# Altium schematic sheet ATK-MS7620.SchDoc
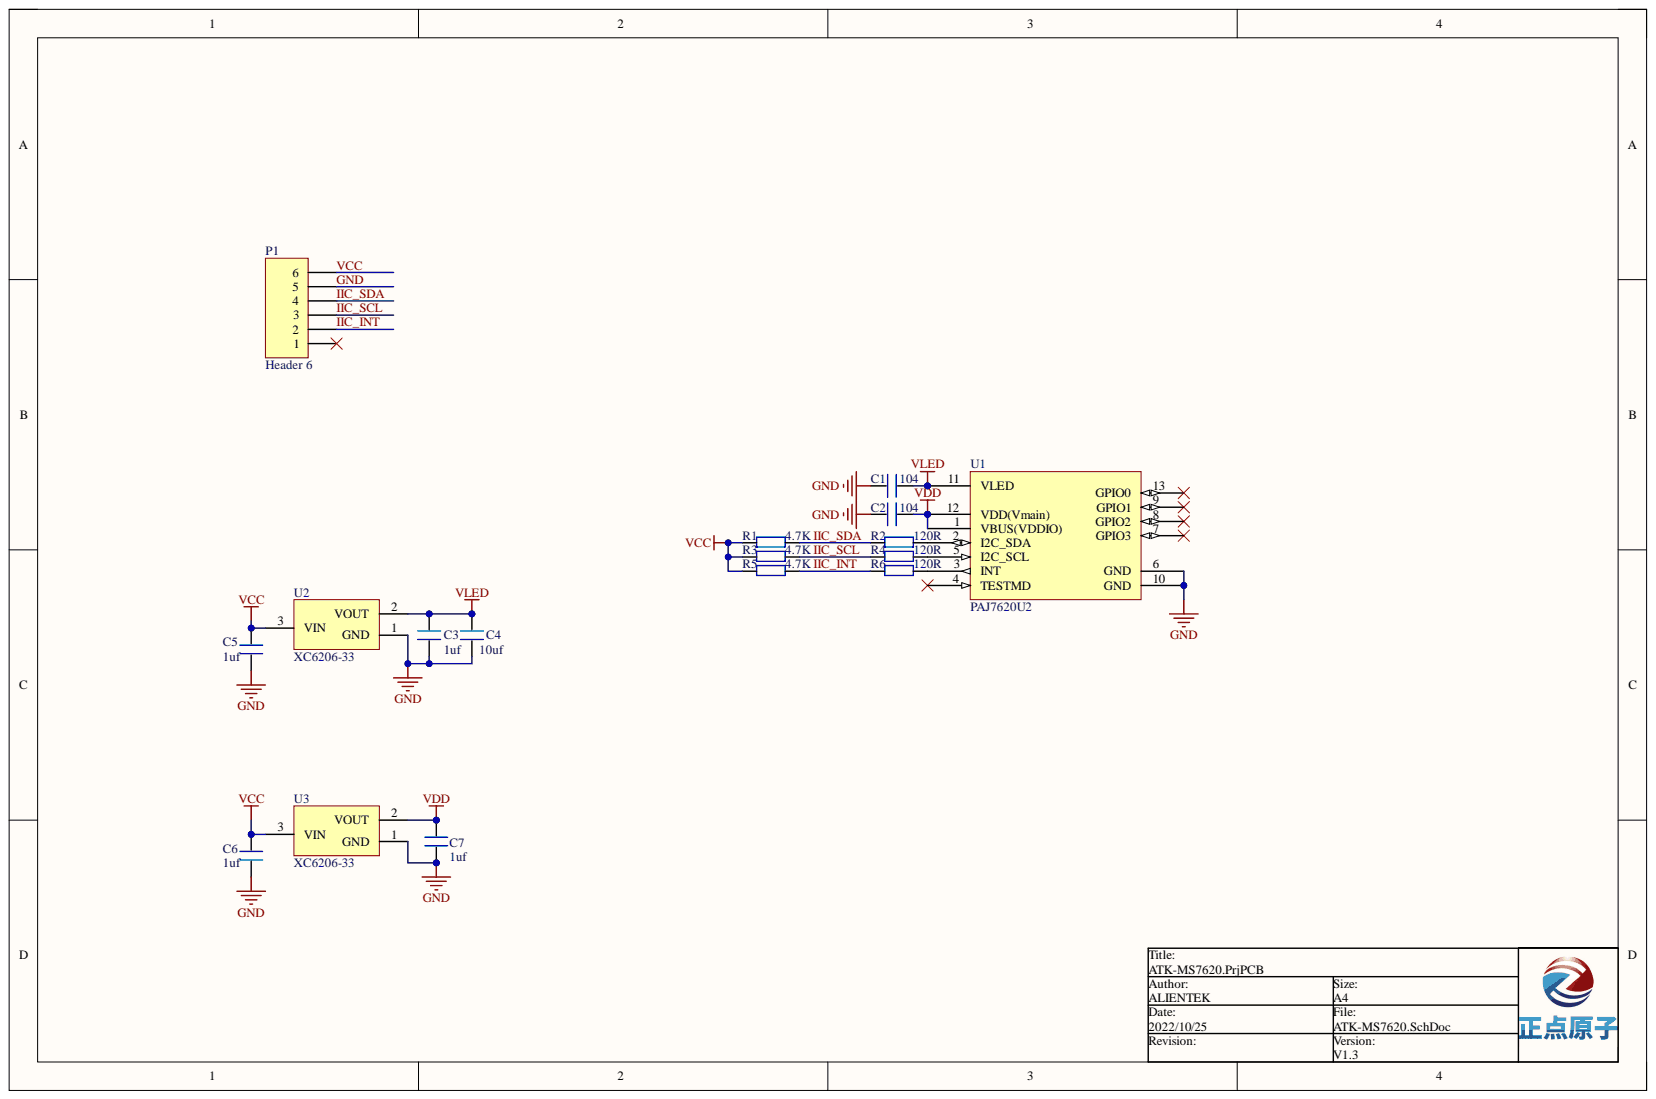
[diagram p0: ATK-MS7620.SchDoc]

## Parts
C1  104  [0603P]
C2  104  [0603P]
C3  1uf  [0603P]
C4  10uf  [0603P]
C5  1uf  [0603P]
C6  1uf  [0603P]
C7  1uf  [0603P]
P1  Header 6  [HDR1X6]
R1  4.7K  [0603P]
R2  120R  [0603P]
R3  4.7K  [0603P]
R4  120R  [0603P]
R5  4.7K  [0603P]
R6  120R  [0603P]
U1  PAJ7620U2  [PAJ7620U2]
U2  XC6206-33  [SOT-23]
U3  XC6206-33  [SOT-23]

## Nets
VDD: C2.2 C7.2 U1.1(VBUS(VDDIO)) U1.12(VDD(Vmain)) U3.2
VLED: C1.1 C3.2 C4.2 U1.11(VLED) U2.2
GND: C1.2 C2.1 C3.1 C4.1 C5.1 C6.1 C7.1 P1.5 U1.6(GND) U1.10(GND) U2.1 U3.1
IIC_INT: P1.2 R5.1 R6.2
IIC_SCL: P1.3 R3.1 R4.2
IIC_SDA: P1.4 R1.1 R2.2
VCC: C5.2 C6.2 P1.6 R1.2 R3.2 R5.2 U2.3 U3.3
NetR2_1: R2.1 U1.2(I2C_SDA)
NetR4_1: R4.1 U1.5(I2C_SCL)
NetR6_1: R6.1 U1.3(INT)

Not connected: P1 1; U1 4(TESTMD) 7(GPIO3) 8(GPIO2) 9(GPIO1) 13(GPIO0)

## Library data of the parts
C1-C7 = C: Component Kind: Standard; Library Name: ATK-2014-09-12111.IntLib
P1 = Header 6: Header, 6-Pin; Component Kind: Standard; LatestRevisionDate: 17-Jul-2002; LatestRevisionNote: Re-released for DXP Platform.; Library Name: Miscellaneous Connectors.IntLib; Signal Integrity: Connector; Publisher: Altium Limited
R1-R6 = R: Component Kind: Standard; Library Name: ATK-2014-09-12111.IntLib
U1 = PAJ7620U2: 手势识别; Component Kind: Standard; Library Name: fantasylib.SchLib
U2 U3 = AIC1734-33: 3.3V LDO 300MA; Component Kind: Standard; Library Name: fantasylib.SchLib
